# Altium schematic sheet PixelClockController.SchDoc
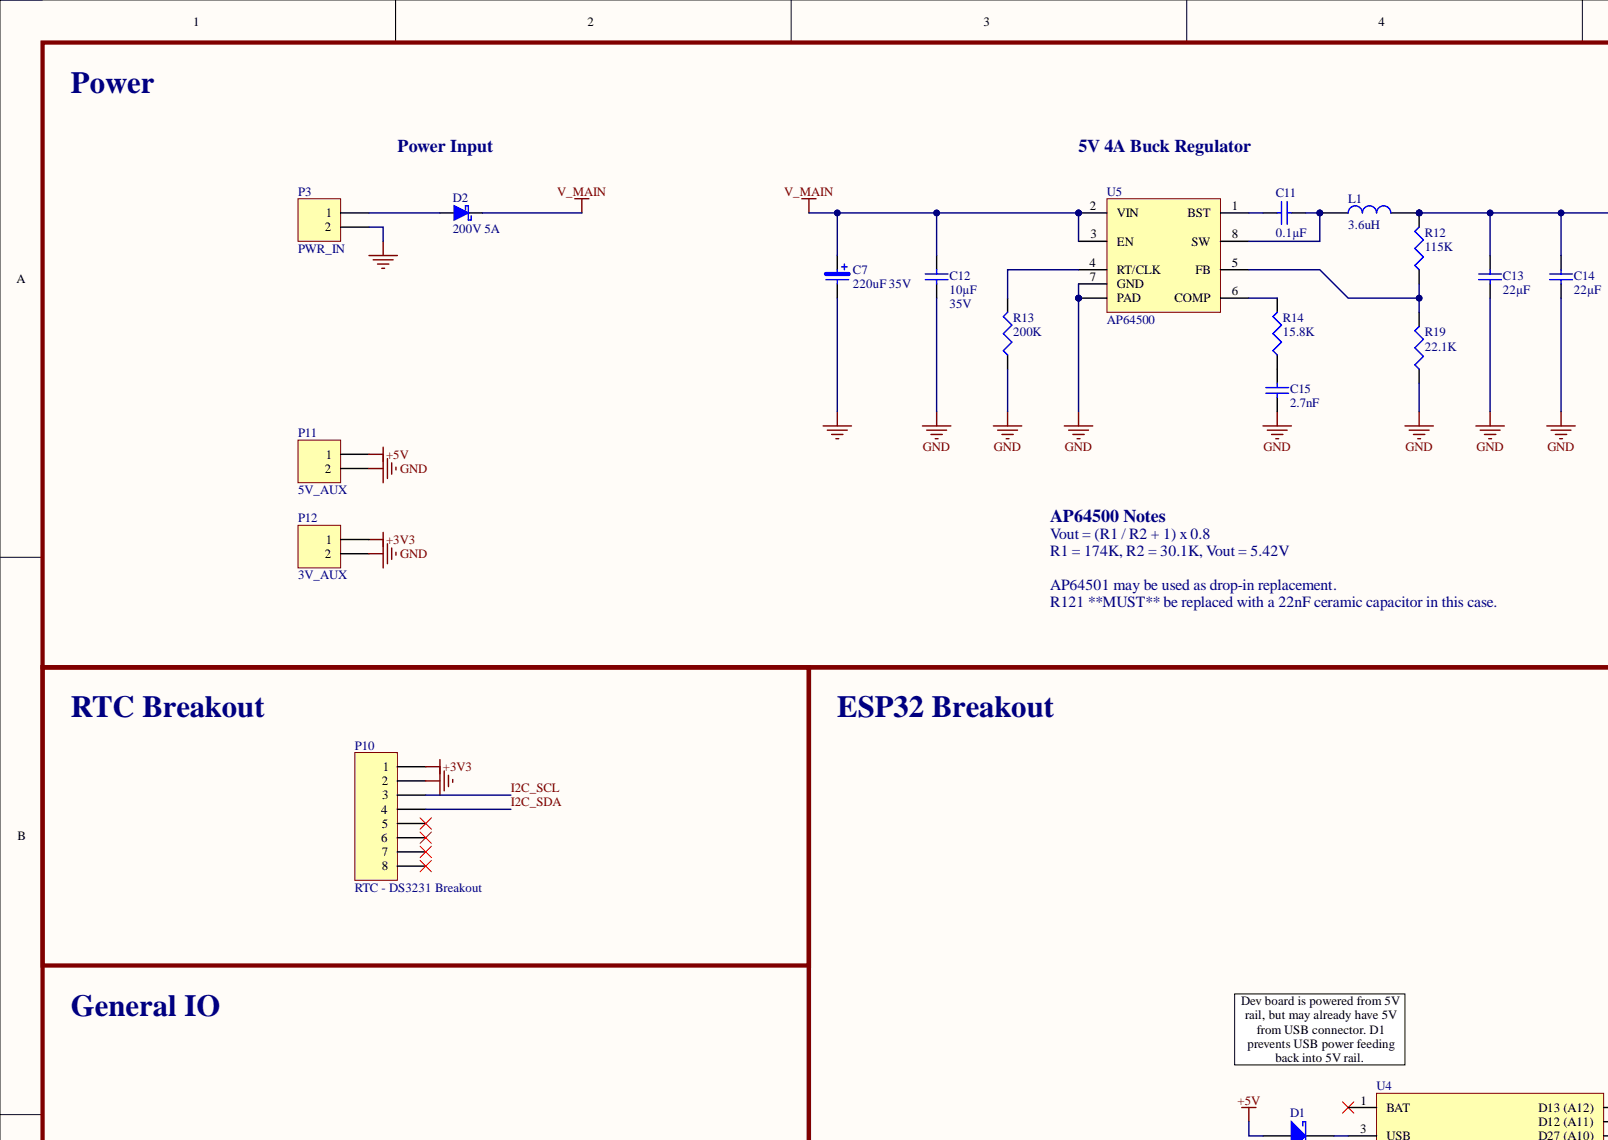
[diagram p0: PixelClockController.SchDoc, top left]
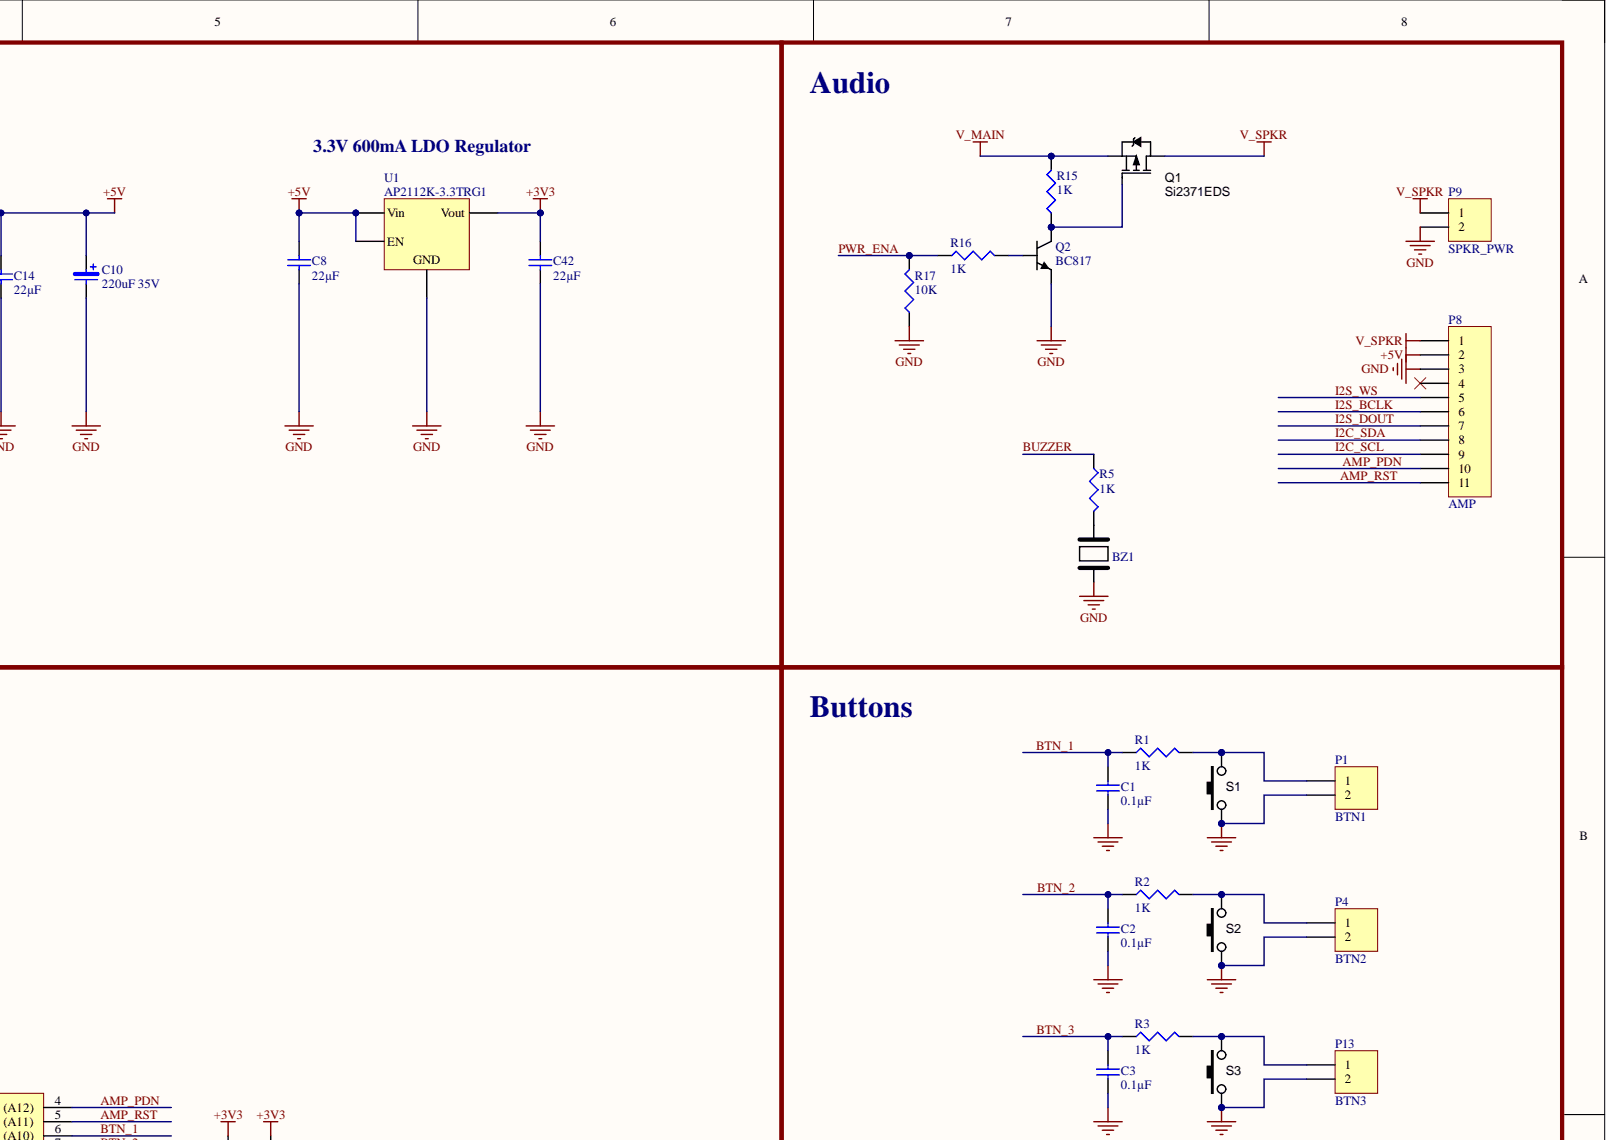
[diagram p1: PixelClockController.SchDoc, top right]
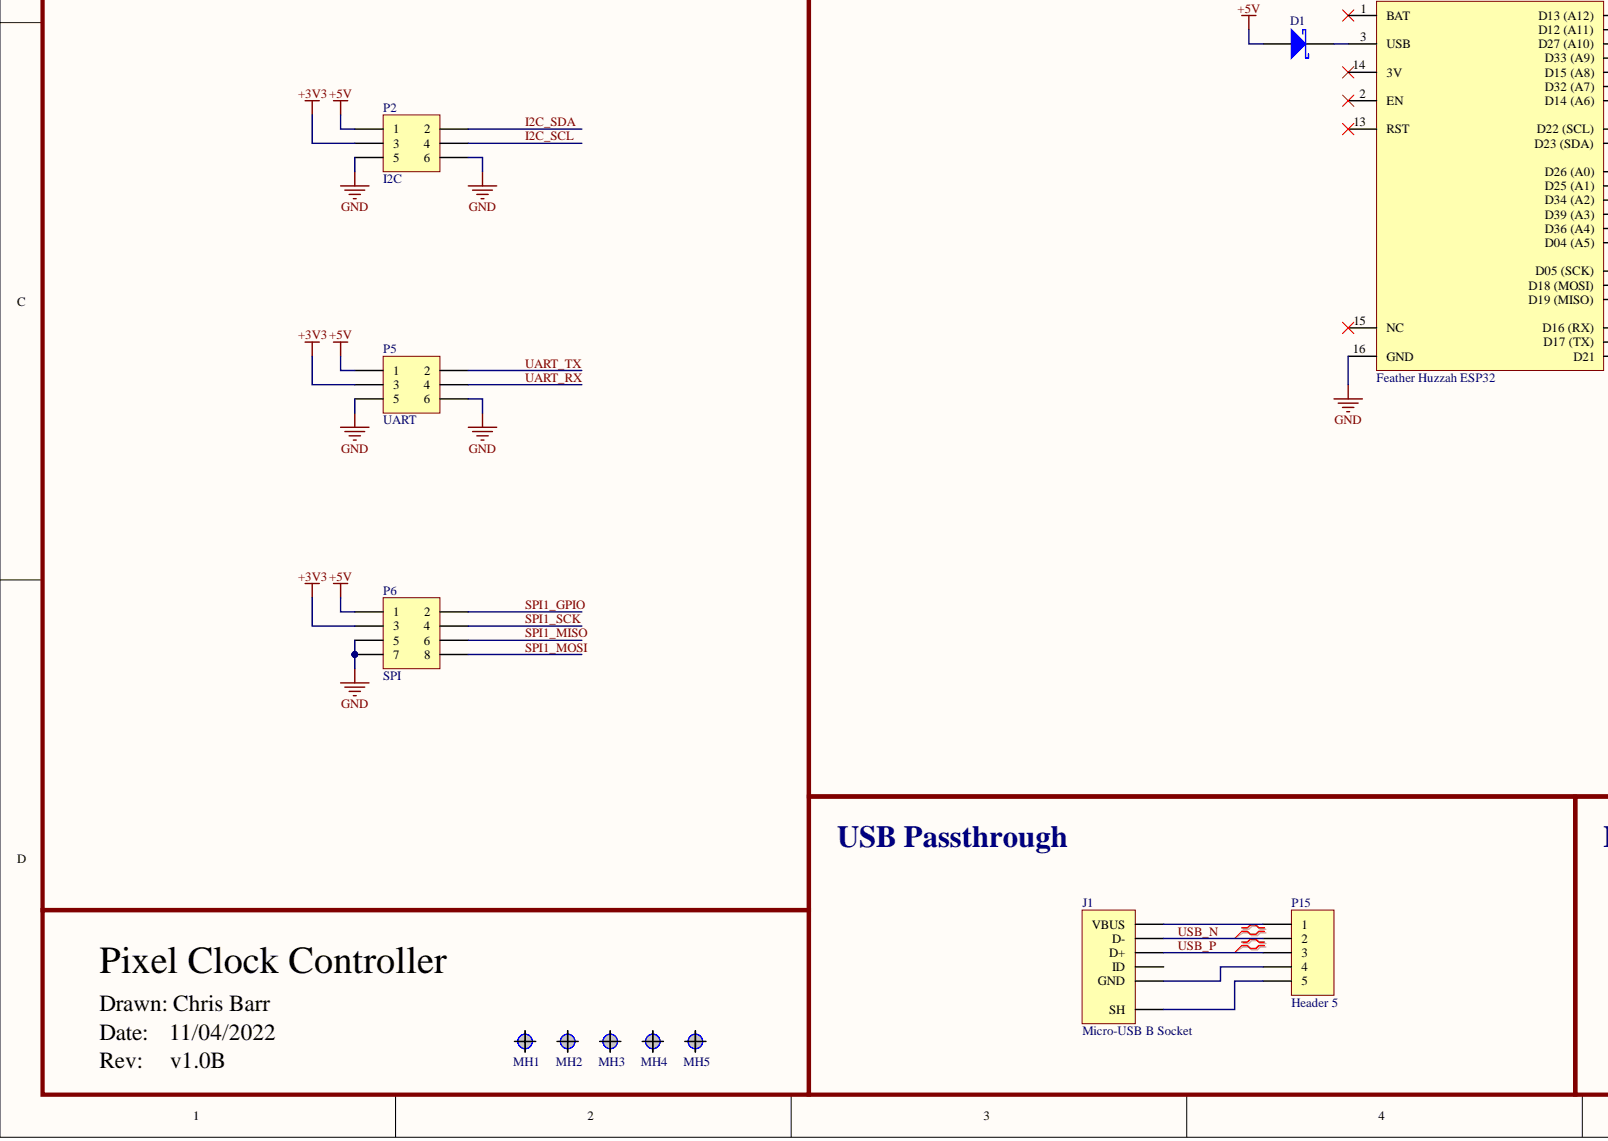
[diagram p2: PixelClockController.SchDoc, bottom left]
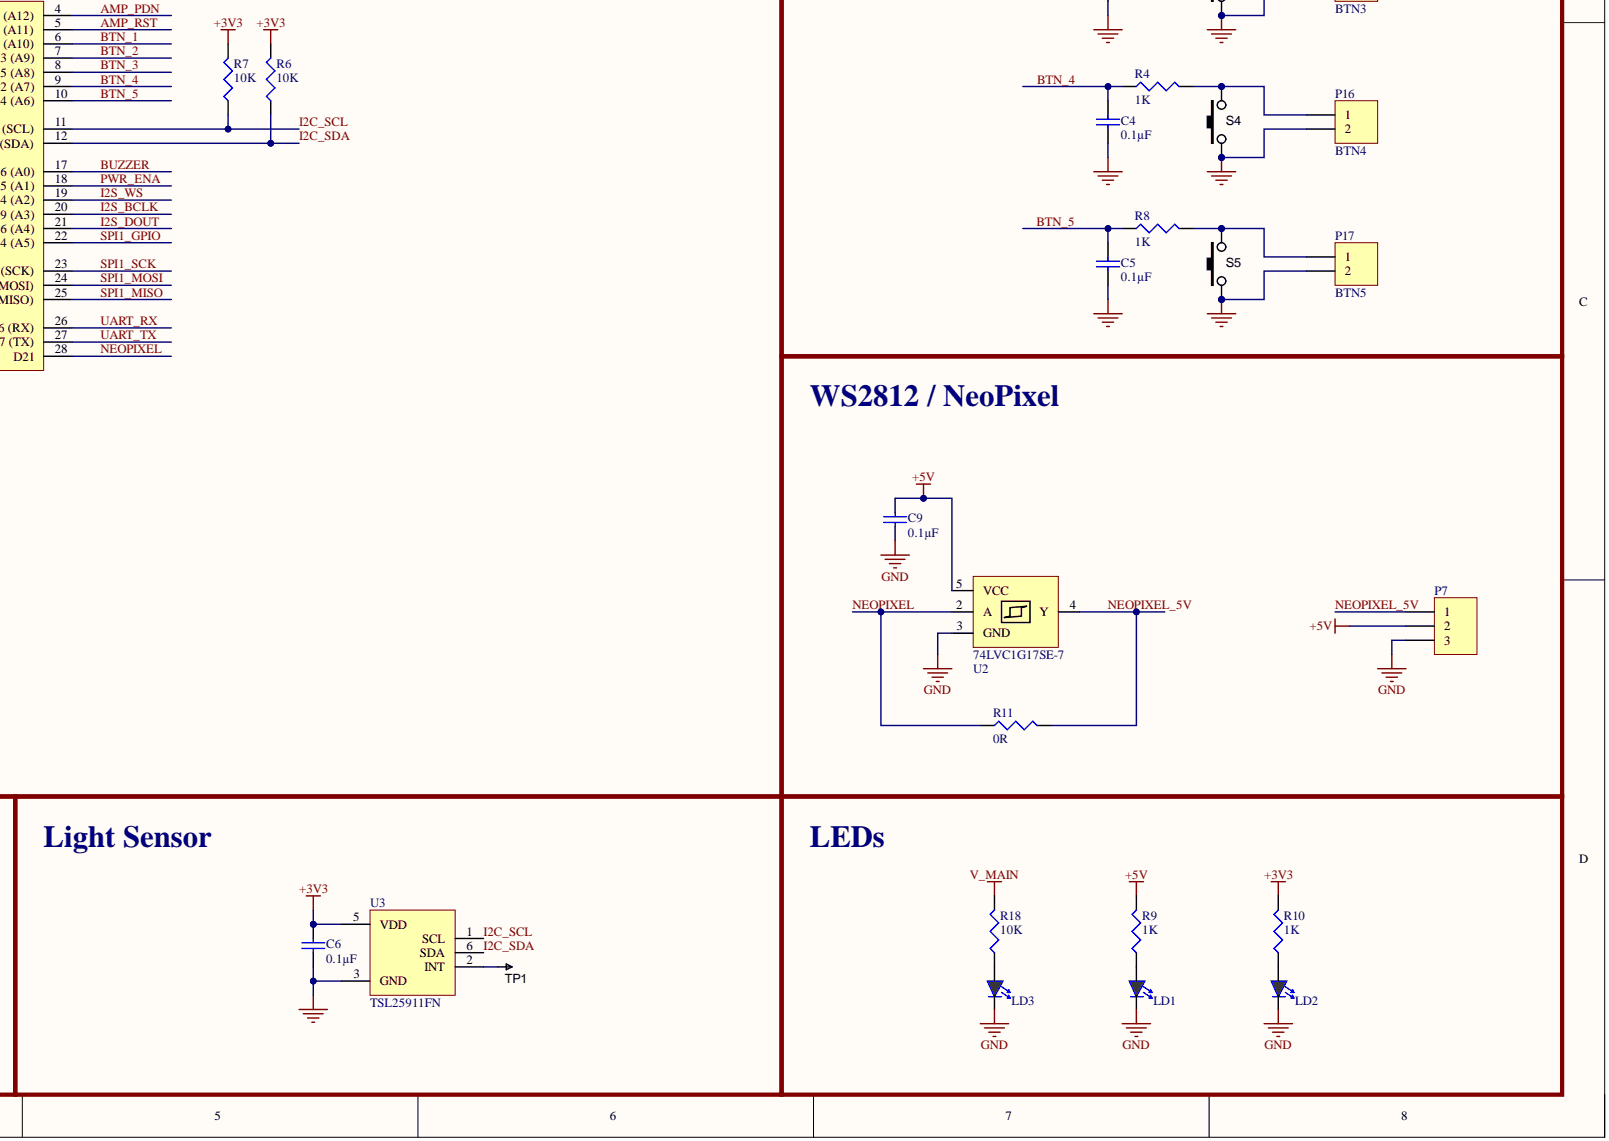
[diagram p3: PixelClockController.SchDoc, bottom right]

## Parts
BZ1  Piezo Transducer  [PKMCS0909E4000-R1]  (value not drawn)
C1  value 0.1µF  [CAPC1608X90N]  (hidden comment: Capacitor)
C2  value 0.1µF  [CAPC1608X90N]  (hidden comment: Capacitor)
C3  value 0.1µF  [CAPC1608X90N]  (hidden comment: Capacitor)
C4  value 0.1µF  [CAPC1608X90N]  (hidden comment: Capacitor)
C5  value 0.1µF  [CAPC1608X90N]  (hidden comment: Capacitor)
C6  value 0.1µF  [CAPC1608X90N]  (hidden comment: Capacitor)
C7  value 220uF 35V  [CAPAE250P680X1220_VERT]  (hidden comment: CapE-8.0-THT-220uF-35V)
C8  value 22µF  [CAPC3216X100N]  (hidden comment: Capacitor)
C9  value 0.1µF  [CAPC1608X90N]  (hidden comment: Capacitor)
C10  value 220uF 35V  [CAPAE250P680X1220_VERT]  (hidden comment: CapE-8.0-THT-220uF-35V)
C11  value 0.1µF  [CAPC1608X90N]  (hidden comment: Capacitor)
C12  value 10µF  Voltage: 35V  [CAPC3225X220N]  (hidden comment: Capacitor)
C13  value 22µF  [CAPC3216X100N]  (hidden comment: Capacitor)
C14  value 22µF  [CAPC3216X100N]  (hidden comment: Capacitor)
C15  value 2.7nF  [CAPC1608X90N]  (hidden comment: Capacitor)
C42  value 22µF  [CAPC3216X100N]  (hidden comment: Capacitor)
D1  (no value)  [DIOM2616X08N]
D2  value 200V 5A  [DO-214AA]  (hidden comment: Diode SK520BTR)
J1  Micro-USB B Socket  [USB3131-XX-0230-X_revB]
L1  value 3.6uH  [INDUCTOR_NR8040]  (hidden comment: Inductor SMD 3.6uH 4.9A)
LD1  LEDG  0603  [LED 1608-0603]  (value not drawn)
LD2  LEDG  0603  [LED 1608-0603]  (value not drawn)
LD3  LEDG  0603  [LED 1608-0603]  (value not drawn)
MH1  Screw Hole  [M3-Hole-2]  (value not drawn)  (no BOM)
MH2  Screw Hole  [M3-Hole-2]  (value not drawn)  (no BOM)
MH3  Screw Hole  [M3-Hole-2]  (value not drawn)  (no BOM)
MH4  Screw Hole  [M3-Hole-2]  (value not drawn)  (no BOM)
MH5  Screw Hole  [M3-Hole-2]  (value not drawn)  (no BOM)
P1  BTN1  [HDR1X2-HEADER]  (no BOM)
P2  I2C  [HDR2X3-Header]  (no BOM)
P3  PWR_IN  [HDR1X2-HEADER]  (no BOM)
P4  BTN2  [HDR1X2-HEADER]  (no BOM)
P5  UART  [HDR2X3-Header]  (no BOM)
P6  SPI  [HDR2X4-HEADER]  (no BOM)
P7  Header 3  [HDR1X3-HEADER]  (value not drawn)  (no BOM)
P8  AMP  [HDR1X11]  (no BOM)
P9  SPKR_PWR  [HDR1X2-HEADER]  (no BOM)
P10  RTC - DS3231 Breakout  [HDR1X8-HEADER]  (no BOM)
P11  5V_AUX  [HDR1X2-HEADER]  (no BOM)
P12  3V_AUX  [HDR1X2-HEADER]  (no BOM)
P13  BTN3  [HDR1X2-HEADER]  (no BOM)
P15  Header 5  [HDR1X5]  (no BOM)
P16  BTN4  [HDR1X2-HEADER]  (no BOM)
P17  BTN5  [HDR1X2-HEADER]  (no BOM)
Q1  Si2371EDS  [SOT23-3 New]
Q2  BC817  [SOT23-3 New]
R1  value 1K  [RES 1608-0603]  (hidden comment: Resistor)
R2  value 1K  [RES 1608-0603]  (hidden comment: Resistor)
R3  value 1K  [RES 1608-0603]  (hidden comment: Resistor)
R4  value 1K  [RES 1608-0603]  (hidden comment: Resistor)
R5  value 1K  [RES 1608-0603]  (hidden comment: Resistor)
R6  value 10K  [RES 1608-0603]  (hidden comment: Resistor)
R7  value 10K  [RES 1608-0603]  (hidden comment: Resistor)
R8  value 1K  [RES 1608-0603]  (hidden comment: Resistor)
R9  value 1K  [RES 1608-0603]  (hidden comment: Resistor)
R10  value 1K  [RES 1608-0603]  (hidden comment: Resistor)
R11  value 0R  [RES 1608-0603]  (hidden comment: Res-0603-0R)
R12  value 115K  [RES 1608-0603]  (hidden comment: Resistor)
R13  value 200K  [RES 1608-0603]  (hidden comment: Resistor)
R14  value 15.8K  [RES 1608-0603]  (hidden comment: Resistor)
R15  value 1K  [RES 1608-0603]  (hidden comment: Resistor)
R16  value 1K  [RES 1608-0603]  (hidden comment: Resistor)
R17  value 10K  [RES 1608-0603]  (hidden comment: Resistor)
R18  value 10K  [RES 1608-0603]  (hidden comment: Resistor)
R19  value 22.1K  [RES 1608-0603]  (hidden comment: Resistor)
S1  SW_THT_PB_4.3mm  [TACT-SWITCH-TS1143]  (value not drawn)
S2  SW_THT_PB_4.3mm  [TACT-SWITCH-TS1143]  (value not drawn)
S3  SW_THT_PB_4.3mm  [TACT-SWITCH-TS1143]  (value not drawn)
S4  SW_THT_PB_4.3mm  [TACT-SWITCH-TS1143]  (value not drawn)
S5  SW_THT_PB_4.3mm  [TACT-SWITCH-TS1143]  (value not drawn)
TP1  TESTPOINT  [TP-R60]  (value not drawn)  (no BOM)
U1  AP2112K-3.3TRG1  [SOT23-5]
U2  74LVC1G17SE-7  [SOT353]
U3  TSL25911FN  [SON65P200X70-6N]
U4  Feather Huzzah ESP32  [Adafruit Feather Huzzah ESP32]
U5  AP64500  [SOIC127P600X140_HS-9N]

## Nets
+3V3: C6.2 C42.2 P2.3 P5.3 P6.3 P10.1 P12.1 R6.2 R7.2 R10.2 U1.5(Vout) U3.5(VDD)
+5V: C8.2 C9.2 C10.1 C13.2 C14.2 D1.A L1.2 P2.1 P5.1 P6.1 P7.2 P8.2 P11.1 R9.2 R12.2 U1.1(Vin) U1.3(EN) U2.5(VCC)
GND: BZ1.2 C1.1 C2.1 C3.1 C4.1 C5.1 C6.1 C7.2 C8.1 C9.1 C10.2 C12.1 C13.1 C14.1 C15.1 C42.1 LD1.2 LD2.2 LD3.2 P1.2 P2.5 P2.6 P3.2 P4.2 P5.5 P5.6 P6.5 P6.7 P7.3 P8.3 P9.2 P10.2 P11.2 P12.2 P13.2 P16.2 P17.2 Q2.2 R13.1 R17.1 R19.1 S1.2 S2.2 S3.2 S4.2 S5.2 U1.2 U2.3(GND) U3.3(GND) U4.16(GND) U5.7(GND) U5.9(PAD)
V_MAIN: C7.1 C12.2 D2.K Q1.2 R15.2 R18.2 U5.2(VIN) U5.3(EN)
V_SPKR: P8.1 P9.1 Q1.3
AMP_PDN: P8.10 U4.4(D13_(A12))
AMP_RST: P8.11 U4.5(D12_(A11))
BTN_1: C1.2 R1.2 U4.6(D27_(A10))
BTN_2: C2.2 R2.2 U4.7(D33_(A9))
BTN_3: C3.2 R3.2 U4.8(D15_(A8))
BTN_4: C4.2 R4.2 U4.9(D32_(A7))
BTN_5: C5.2 R8.2 U4.10(D14_(A6))
BUZZER: R5.1 U4.17(D26_(A0))
I2C_SCL: P2.4 P8.9 P10.3 R7.1 U3.1(SCL) U4.11(D22_(SCL))
I2C_SDA: P2.2 P8.8 P10.4 R6.1 U3.6(SDA) U4.12(D23_(SDA))
I2S_BCLK: P8.6 U4.20(D39_(A3))
I2S_DOUT: P8.7 U4.21(D36_(A4))
I2S_WS: P8.5 U4.19(D34_(A2))
NEOPIXEL: R11.1 U2.2(A) U4.28(D21)
NEOPIXEL_5V: P7.1 R11.2 U2.4(Y)
PWR_ENA: R16.1 R17.2 U4.18(D25_(A1))
SPI1_GPIO: P6.2 U4.22(D04_(A5))
SPI1_MISO: P6.6 U4.25(D19_(MISO))
SPI1_MOSI: P6.8 U4.24(D18_(MOSI))
SPI1_SCK: P6.4 U4.23(D05_(SCK))
UART_RX: P5.4 U4.26(D16_(RX))
UART_TX: P5.2 U4.27(D17_(TX))
USB_N: J1.2(D-) P15.2
USB_P: J1.3(D+) P15.3
NetBZ1_1: BZ1.1 R5.2
NetC11_1: C11.1 L1.1 U5.8(SW)
NetC11_2: C11.2 U5.1(BST)
NetC15_2: C15.2 R14.1
NetD1_K: D1.K U4.3(USB)
NetD2_A: D2.A P3.1
NetJ1_1: J1.1(VBUS) P15.1
NetJ1_5: J1.5(GND) P15.4
NetJ1_S: J1.S(SH) P15.5
NetLD1_1: LD1.1 R9.1
NetLD2_1: LD2.1 R10.1
NetLD3_1: LD3.1 R18.1
NetP1_1: P1.1 R1.1 S1.1
NetP4_1: P4.1 R2.1 S2.1
NetP13_1: P13.1 R3.1 S3.1
NetP16_1: P16.1 R4.1 S4.1
NetP17_1: P17.1 R8.1 S5.1
NetQ1_1: Q1.1 Q2.3 R15.1
NetQ2_1: Q2.1 R16.2
NetR12_1: R12.1 R19.2 U5.5(FB)
NetR13_2: R13.2 U5.4(RT/CLK)
NetR14_2: R14.2 U5.6(COMP)
NetTP1_1: TP1.1 U3.2(INT)

Not connected: J1 4(ID); MH1 M3; MH2 M3; MH3 M3; MH4 M3; MH5 M3; P8 4; P10 5 6 7 8; U4 1(BAT) 2(EN) 13(RST) 14(3V) 15(NC)

## Library data of the parts
BZ1 = Piezo Transducer: AUDIO PIEZO TRANSDUCER 12.5V SMD 9 x 9MM; Component Kind: Standard; Library Name: main_library.SCHLIB; Supplier 1: Digi-Key; Supplier Part Number 1: 490-9647-1-ND
C1-C6 C9 C11 = UMJ107BB7104KAHT: CAP CER 0.1UF 50V X7R 0603; Manufacturer 1: Taiyo Yuden; Database Table Name: TaiyoYuden$; Note: Approved sub OK; Tolerance: ±10%; Type: X5R; Component Kind: Standard; Library Name: Ceramic Cap DBLIB.DbLib; Manufacturer Part Number 1: UMJ107BB7104KAHT; Operating Temperature: -55°C ~ 125°C; Package: 0402; Package / Case: 0603 (1608 Metric); Revision: July-2002: Re-released for DXP Platform.; Signal Integrity: Cap Semi; Simulation: CAP; Size / Dimension: 0.063" L x 0.031" W (1.60mm x 0.80mm); Supplier 1: Digi-Key; Supplier Part Number 1: 587-4474-1-ND; Temperature Coefficient: X7R; Thickness (Max): 0.039" (1.00mm); Voltage: 50V
C7 = CapE-8.0-THT-220uF-35V: CAP ALUM 220UF 20% 35V RADIAL; Note: Approved sub OK; Value: 220uF 35V; Component Kind: Standard; Library Name: main_library.SCHLIB; Published: 8-Jun-2000; Revision: July-2002: Re-released for DXP Platform.; Signal Integrity: Cap Pol; Simulation: CAP; Supplier 1: Digi-Key; Supplier Part Number 1: 732-8946-1-ND; Publisher: Altium Limited
C8 C13 C14 C42 = EMK316ABJ226KL-T: CAP CER 22UF 16V X5R 1206; Manufacturer 1: Taiyo Yuden; Database Table Name: TaiyoYuden$; Note: Approved sub OK; Tolerance: ±10%; Component Kind: Standard; Library Name: Ceramic Cap DBLIB.DbLib; Manufacturer Part Number 1: EMK316ABJ226KL-T; Operating Temperature: -55°C ~ 85°C; Package: 0402; Package / Case: 1206 (3216 Metric); Revision: July-2002: Re-released for DXP Platform.; Signal Integrity: Cap Semi; Simulation: CAP; Size / Dimension: 0.126" L x 0.063" W (3.20mm x 1.60mm); Supplier 1: Digi-Key; Supplier Part Number 1: 587-5521-1-ND; Temperature Coefficient: X5R; Thickness (Max): 0.071" (1.80mm); Type: X5R; Voltage: 16V
C10 = CapE-8.0-THT-220uF-35V: CAP ALUM 220UF 20% 35V RADIAL; Note: Approved sub OK; Component Kind: Standard; Library Name: main_library.SCHLIB; Published: 8-Jun-2000; Revision: July-2002: Re-released for DXP Platform.; Signal Integrity: Cap Pol; Simulation: CAP; Supplier 1: Digi-Key; Supplier Part Number 1: 732-8946-1-ND; Publisher: Altium Limited
C12 = GMJ325KB7106KMHP: CAP CER 10UF 35V X7R 1210; Manufacturer 1: Taiyo Yuden; Database Table Name: TaiyoYuden$; Note: Approved sub OK; Tolerance: ±10%; Type: X5R; Component Kind: Standard; Library Name: Ceramic Cap DBLIB.DbLib; Manufacturer Part Number 1: GMJ325KB7106KMHP; Operating Temperature: -55°C ~ 125°C; Package: 0402; Package / Case: 1210 (3225 Metric); Revision: July-2002: Re-released for DXP Platform.; Signal Integrity: Cap Semi; Simulation: CAP; Size / Dimension: 0.126" L x 0.098" W (3.20mm x 2.50mm); Supplier 1: Digi-Key; Supplier Part Number 1: 587-4890-1-ND; Temperature Coefficient: X7R; Thickness (Max): 0.110" (2.80mm)
C15 = C1608X7R2A222K080AE: CAP CER 2700PF 50V X7R 0603; Manufacturer 1: TDK Corporation; Database Table Name: TDK$; Note: Approved sub OK; Tolerance: ±10%; Type: X5R; Voltage: 100V; Component Kind: Standard; Library Name: Ceramic Cap DBLIB.DbLib; Manufacturer Part Number 1: C1608X7R2A222K080AE; Operating Temperature: -55°C ~ 125°C; Package: 0402; Package / Case: 0603 (1608 Metric); Revision: July-2002: Re-released for DXP Platform.; Signal Integrity: Cap Semi; Simulation: CAP; Size / Dimension: 0.063" L x 0.031" W (1.60mm x 0.80mm); Supplier 1: Digi-Key; Supplier Part Number 1: 1292-1423-2-ND; Temperature Coefficient: X7R; Thickness (Max): 0.035" (0.90mm)
D1 = Diode Schottky 2A 40V (SS24FL): DIODE SCHOTTKY 40V 2A SOD123F; Manufacturer 1: ON Semiconductor; Manufacturer Part Number 1: SS24FL; Code - JEDEC: SOD-123F; Note: Approved sub OK; Component Kind: Standard; Published: 8-Jun-2000; Revision: July-2002: Re-released for DXP Platform.; Signal Integrity: Diode; Simulation: DIODE; Supplier 1: Digi-Key; Supplier Part Number 1: SS24FLCT-ND; Library Name: picoprint_library.SCHLIB; Publisher: Altium Limited
D2 = Diode SK520BTR: DIODE SCHOTTKY 200V 5A SMB; Code - JEDEC: DO-214-AB; Component Kind: Standard; Library Name: main_library.SCHLIB; Published: 8-Jun-2000; Revision: July-2002: Re-released for DXP Platform.; Supplier 1: Digi-Key; Supplier Part Number 1: 1655-1602-1-ND; Publisher: Altium Limited
J1 = Micro-USB B Socket Vertical: MICRO B SKT, VERTICAL, TH, 30",; Note: Approved sub OK; Component Kind: Standard; Library Name: main_library.SCHLIB; Supplier 1: Digi-Key; Supplier Part Number 1: 2073-USB3131-30-0230-ACT-ND
L1 = Inductor SMD 3.6uH 4.9A: FIXED IND 3.6UH 4.9A 19.5 MOHM; Component Kind: Standard; Library Name: main_library.SCHLIB; Published: 24-Mar-1999; Revision: July-2002: Re-released for DXP Platform.; Supplier 1: Digi-Key; Supplier Part Number 1: 587-1998-1-ND; Publisher: Altium Limited
LD1-LD3 = LEDG  0603: LED GREEN CLEAR CHIP SMD 0603; Note: Approved sub OK; Component Kind: Standard; Library Name: main_library.SCHLIB; Supplier 1: Digi-Key; Supplier Part Number 1: 160-1435-2-ND
P1 P3 P4 P9 P11-P13 P16 P17 = Header 1X2: Header, 2-Pin; Manufacturer 1: Generic Header; Note: Approved sub OK; Library Name: main_library.SCHLIB; Signal Integrity: Connector
P2 P5 = Header 2X3: Header, 3-Pin, Dual row; Manufacturer 1: Generic Header; Note: Approved sub OK; Library Name: main_library.SCHLIB; Signal Integrity: Connector
P6 = Header 2X4: Header, 4-Pin, Dual row; Manufacturer 1: Generic Header; Note: Approved sub OK; Library Name: main_library.SCHLIB; Signal Integrity: Connector
P7 = Header 1X3: Header, 3-Pin; Manufacturer 1: Generic Header; Note: Approved sub OK; Library Name: main_library.SCHLIB; Signal Integrity: Connector
P8 = Header 11: Header, 11-Pin; LatestRevisionDate: 17-Jul-2002; LatestRevisionNote: Re-released for DXP Platform.; Library Name: Miscellaneous Connectors.IntLib; Signal Integrity: Connector; Publisher: Altium Limited
P10 = Header 1X8: Header, 8-Pin; Manufacturer 1: Generic Header; Note: Approved sub OK; Library Name: main_library.SCHLIB; Signal Integrity: Connector
P15 = Header 5: Header, 5-Pin; LatestRevisionDate: 17-Jul-2002; LatestRevisionNote: Re-released for DXP Platform.; Library Name: Miscellaneous Connectors.IntLib; Signal Integrity: Connector; Publisher: Altium Limited
Q1 = MOSFET - Si2371EDS: MOSFET SMD P-CH 30V 4.8A SOT-23-3; FET Type: MOSFET P-Channel, Metal Oxide; Component Kind: Standard; Library Name: main_library.SCHLIB; Supplier 1: Digi-Key; Supplier Part Number 1: SI2371EDS-T1-GE3CT-ND
Q2 = Transistor - NPN - 45V 500MA - BC817: TRANS NPN 45V 500MA TO236AB; Component Kind: Standard; Library Name: main_library.SCHLIB; Supplier 1: Digi-Key; Supplier Part Number 1: 1727-6186-1-ND
R1-R5 R8-R10 R15 R16 = RC0603FR-071KL: RES SMD 1K OHM 1% 1/10W 0603; Manufacturer 1: Yageo; Database Table Name: 0603$; Note: Approved sub OK; Power (Watts): 0.1W, 1/10W; Temperature Coefficient: ±100ppm/°C; Component Kind: Standard; Composition: Thick Film; Features: Moisture Resistant; Height - Seated (Max): 0.022" (0.55mm); Library Name: Database_Libs1.DbLib; Manufacturer Part Number 1: RC0603FR-071KL; Operating Temperature: -55°C ~ 155°C; Package / Case: 0603 (1608 Metric); Published: 8-Jun-2000; Revision: July-2002: Re-released for DXP Platform.; Signal Integrity: Res; Simulation: RESISTOR; Size / Dimension: 0.063" L x 0.031" W (1.60mm x 0.80mm); Supplier 1: Digi-Key; Supplier Part Number 1: 311-1.00KHRCT-ND; Tolerance: ±1%; Publisher: Altium Limited
R6 R7 R17 R18 = RC0603FR-0710KL: RES SMD 10K OHM 1% 1/10W 0603; Manufacturer 1: Yageo; Database Table Name: 0603$; Note: Approved sub OK; Power (Watts): 0.1W, 1/10W; Temperature Coefficient: ±100ppm/°C; Component Kind: Standard; Composition: Thick Film; Features: Moisture Resistant; Height - Seated (Max): 0.022" (0.55mm); Library Name: Database_Libs1.DbLib; Manufacturer Part Number 1: RC0603FR-0710KL; Operating Temperature: -55°C ~ 155°C; Package / Case: 0603 (1608 Metric); Published: 8-Jun-2000; Revision: July-2002: Re-released for DXP Platform.; Signal Integrity: Res; Simulation: RESISTOR; Size / Dimension: 0.063" L x 0.031" W (1.60mm x 0.80mm); Supplier 1: Digi-Key; Supplier Part Number 1: 311-10.0KHRCT-ND; Tolerance: ±1%; Publisher: Altium Limited
R11 = Res-0603-0R: RES 0 OHM JUMPER 1/10W 0603; Note: Approved sub OK; Component Kind: Standard; Library Name: main_library.SCHLIB; Published: 8-Jun-2000; Revision: July-2002: Re-released for DXP Platform.; Signal Integrity: Res; Simulation: RESISTOR; Supplier 1: Digi-Key; Supplier Part Number 1: 311-0.0GRCT-ND; Publisher: Altium Limited
R12 = RC0603FR-07115KL: RES SMD 115K OHM 1% 1/10W 0603; Manufacturer 1: Yageo; Database Table Name: 0603$; Note: Approved sub OK; Power (Watts): 0.1W, 1/10W; Temperature Coefficient: ±100ppm/°C; Component Kind: Standard; Composition: Thick Film; Features: Moisture Resistant; Height - Seated (Max): 0.022" (0.55mm); Library Name: Database_Libs1.DbLib; Manufacturer Part Number 1: RC0603FR-07115KL; Operating Temperature: -55°C ~ 155°C; Package / Case: 0603 (1608 Metric); Published: 8-Jun-2000; Revision: July-2002: Re-released for DXP Platform.; Signal Integrity: Res; Simulation: RESISTOR; Size / Dimension: 0.063" L x 0.031" W (1.60mm x 0.80mm); Supplier 1: Digi-Key; Supplier Part Number 1: 311-115KHRCT-ND; Tolerance: ±1%; Publisher: Altium Limited
R13 = RC0603FR-07200KL: RES SMD 200K OHM 1% 1/10W 0603; Manufacturer 1: Yageo; Database Table Name: 0603$; Note: Approved sub OK; Power (Watts): 0.1W, 1/10W; Temperature Coefficient: ±100ppm/°C; Component Kind: Standard; Composition: Thick Film; Features: Moisture Resistant; Height - Seated (Max): 0.022" (0.55mm); Library Name: Database_Libs1.DbLib; Manufacturer Part Number 1: RC0603FR-07200KL; Operating Temperature: -55°C ~ 155°C; Package / Case: 0603 (1608 Metric); Published: 8-Jun-2000; Revision: July-2002: Re-released for DXP Platform.; Signal Integrity: Res; Simulation: RESISTOR; Size / Dimension: 0.063" L x 0.031" W (1.60mm x 0.80mm); Supplier 1: Digi-Key; Supplier Part Number 1: 311-200KHRCT-ND; Tolerance: ±1%; Publisher: Altium Limited
R14 = RC0603FR-0715K8L: RES SMD 15.8K OHM 1% 1/10W 0603; Manufacturer 1: Yageo; Database Table Name: 0603$; Note: Approved sub OK; Power (Watts): 0.1W, 1/10W; Temperature Coefficient: ±100ppm/°C; Component Kind: Standard; Composition: Thick Film; Features: Moisture Resistant; Height - Seated (Max): 0.022" (0.55mm); Library Name: Database_Libs1.DbLib; Manufacturer Part Number 1: RC0603FR-0715K8L; Operating Temperature: -55°C ~ 155°C; Package / Case: 0603 (1608 Metric); Published: 8-Jun-2000; Revision: July-2002: Re-released for DXP Platform.; Signal Integrity: Res; Simulation: RESISTOR; Size / Dimension: 0.063" L x 0.031" W (1.60mm x 0.80mm); Supplier 1: Digi-Key; Supplier Part Number 1: 311-15.8KHRCT-ND; Tolerance: ±1%; Publisher: Altium Limited
R19 = RC0603FR-0722K1L: RES SMD 22.1K OHM 1% 1/10W 0603; Manufacturer 1: Yageo; Database Table Name: 0603$; Note: Approved sub OK; Power (Watts): 0.1W, 1/10W; Temperature Coefficient: ±100ppm/°C; Component Kind: Standard; Composition: Thick Film; Features: Moisture Resistant; Height - Seated (Max): 0.022" (0.55mm); Library Name: Database_Libs1.DbLib; Manufacturer Part Number 1: RC0603FR-0722K1L; Operating Temperature: -55°C ~ 155°C; Package / Case: 0603 (1608 Metric); Published: 8-Jun-2000; Revision: July-2002: Re-released for DXP Platform.; Signal Integrity: Res; Simulation: RESISTOR; Size / Dimension: 0.063" L x 0.031" W (1.60mm x 0.80mm); Supplier 1: Digi-Key; Supplier Part Number 1: 311-22.1KHRCT-ND; Tolerance: ±1%; Publisher: Altium Limited
S1-S5 = SW_THT_PB_4.3mm: 6 X 6 MM, 12 MM ACTUATOR HEIGHT; Manufacturer 1: CUI Devices; Manufacturer Part Number 1: TS02-66-120-BK-160-LCR-D; Component Kind: Standard; Library Name: PBL - Switches.SCHLIB; Material: Brass/Nylon/Ag plating; Package / Case: 6.5x6.5mm; 4.3mm stem; Supplier 1: Digi-Key; Supplier Part Number 1: 2223-TS02-66-120-BK-160-LCR-D-ND
TP1 = TESTPOINT: SMD test pin; Library Name: PBL - Test Points.SCHLIB
U1 = Regulator - AP2112K 3.3V Linear Regulator: IC REG LINEAR 3.3V 600MA SOT25; Manufacturer 1: Diodes Incorporated; Component Kind: Standard; Manufacturer Part Number 1: AP2112K-3.3TRG1; Supplier 1: Digi-Key; Supplier Part Number 1: AP2112K-3.3TRG1DICT-ND; Library Name: picoprint_library.SCHLIB
U2 = Buffer Non-Inverting Schmitt Trigger 74LVC1G17SE-7: IC BUF NON-INVERT 5.5V SOT353; Component Kind: Standard; Supplier 1: Digi-Key; Supplier Part Number 1: 74LVC1G17SE-7CT-ND; Library Name: picoprint_library.SCHLIB
U3 = IC - Optical Sensor Ambient - I²C 6-WFDFN: SENSOR OPT AMBIENT 6DFN; Component Kind: Standard; Library Name: main_library.SCHLIB; Supplier 1: Digi-Key; Supplier Part Number 1: TSL25911FNCT-ND
U4 = Adafruit Feather - ESP32 Huzzah: Component Kind: Standard; Library Name: main_library.SCHLIB
U5 = Regulator - AP64500 Buck Regulator: IC DCDC CONV HV BUCK SO-8EP; Component Kind: Standard; Library Name: main_library.SCHLIB; Supplier 1: Digi-Key; Supplier Part Number 1: 31-AP64500SP-13CT-ND
MH1-MH5 = Screw Hole: Library Name: main_library.SCHLIB
